# Altium schematic sheet Pedalbox.SchDoc
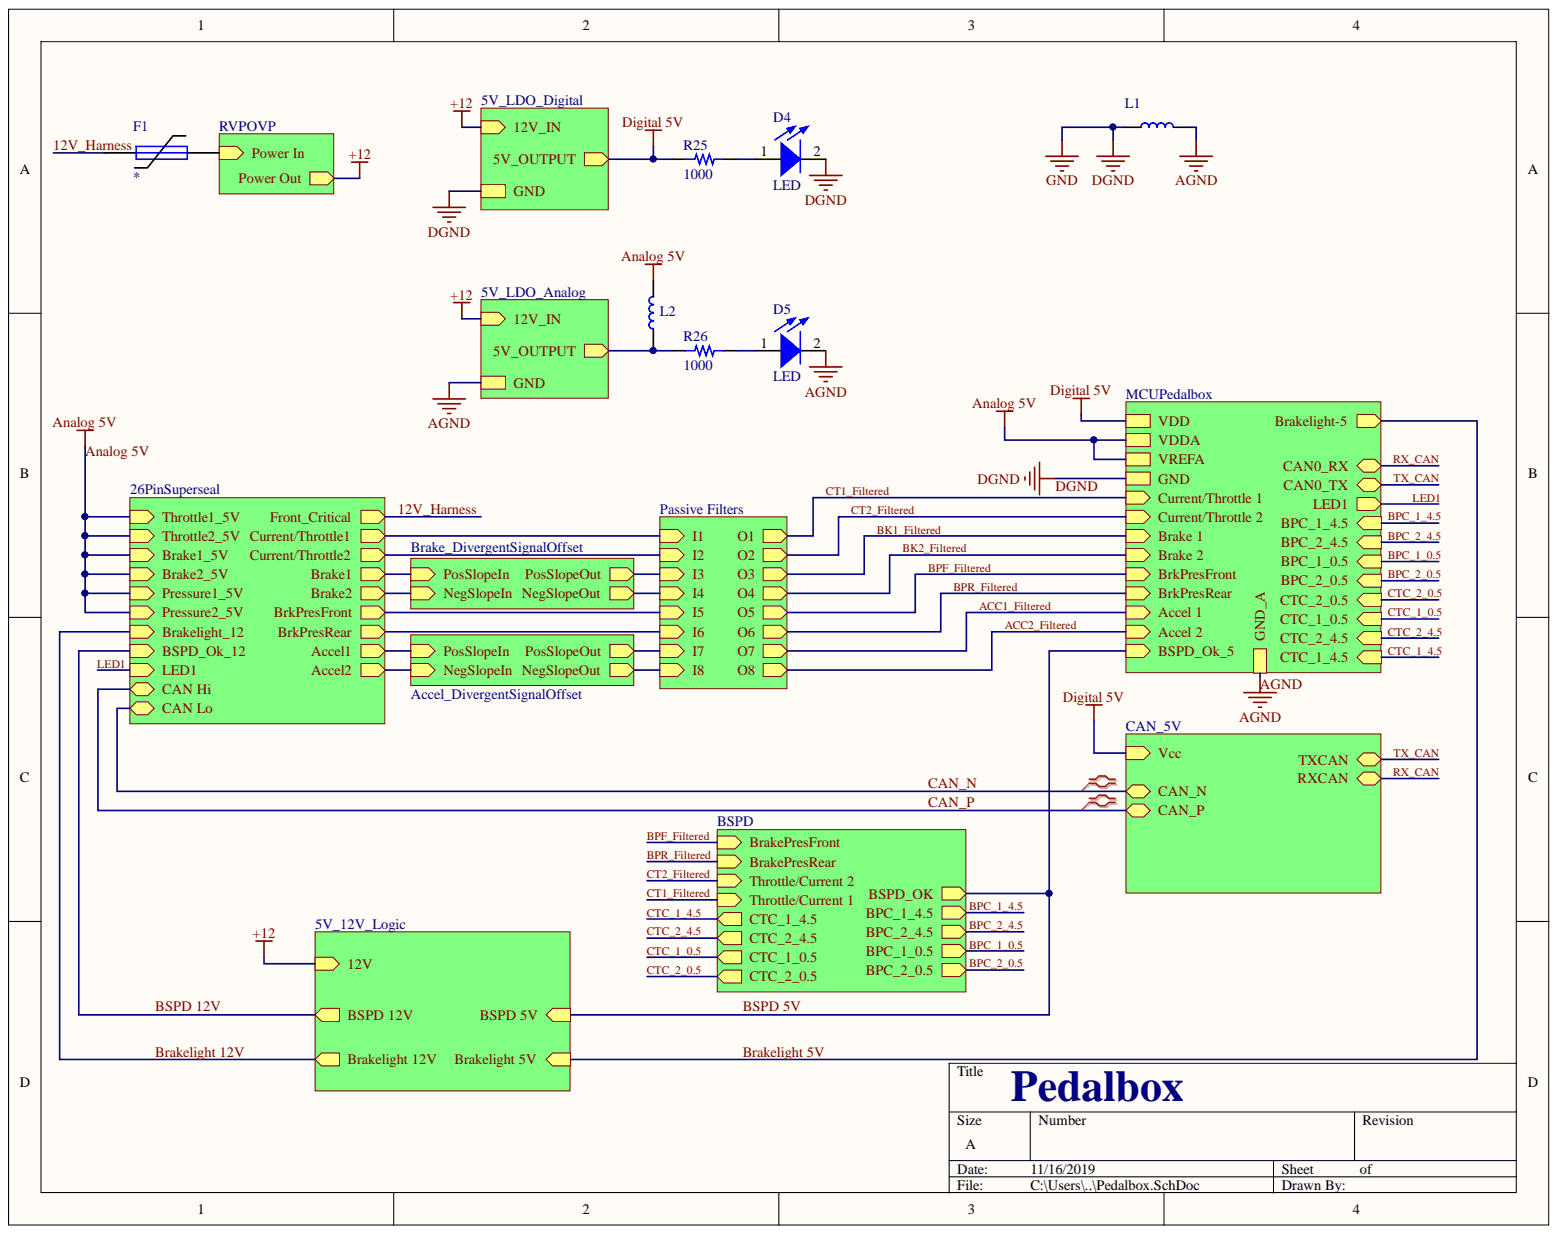
[diagram p0: Pedalbox.SchDoc]

## Parts
D4  LED  [0805_LED]
D5  LED  [0805_LED]
F1  =I_trip  [PTC Fuse 2920/07351]
L1  MPZ1608S221ATA00  [0603]  (value not drawn)
L2  MPZ1608S221ATA00  [0603]  (value not drawn)
R25  resistance: 1000  [0603]  (hidden comment: resistor)
R26  resistance: 1000  [0603]  (hidden comment: resistor)

## Nets
Digital 5V: R25.1
+12: F1.1  [also labelled 12V_Harness]
AGND: D5.2 L1.2
Analog 5V: L2.2
DGND: D4.2 L1.1
NetD4_1: D4.1 R25.2
NetD5_1: D5.1 R26.2
NetF1_2: F1.2
NetL2_1: L2.1 R26.1
ACC1_Filtered:
ACC2_Filtered:
Accel1:
Accel2:
BK1_Filtered:
BK2_Filtered:
BPC_1_0.5:
BPC_1_4.5:
BPC_2_0.5:
BPC_2_4.5:
BPF_Filtered:
BPR_Filtered:
Brake1:
Brake2:
Brakelight 5V:
Brakelight 12V:
BrkPresFront:
BrkPresRear:
BSPD 5V:
BSPD 12V:
CAN_N:
CAN_P:
CT1_Filtered:
CT2_Filtered:
CTC_1_0.5:
CTC_1_4.5:
CTC_2_0.5:
CTC_2_4.5:
Current/Throttle1:
Current/Throttle2:
LED1:
NegSlopeOut:
PosSlopeOut:
RX_CAN:
TX_CAN:

## Library data of the parts
D4 D5 = 19: Generic 0805 LED; Database Table Name: diodes; numcircuits: 1; Component Kind: Standard; id: 19; name: 0805 LED; package: 0805; quantity: 0; vr: 1; Library Name: altlib.DbLib
F1 = 11: Polymeric PTC Resettable Fuse 24V 2A Ih Surfa; Database Table Name: fuses; iinterrupt: 0; itrip: 4; Name: 0ZCF0200AF2C; vmax: 24; Component Kind: Standard; id: 11; LatestRevisionDate: 17-Jul-2002; LatestRevisionNote: Re-released for DXP Platform.; link: https://www.digikey.com/product-detail/en/bel-fuse-inc/0ZCF0200AF2C/507-1749-1-ND/4156183; PackageDescription: Thru-Hole; 2 Leads; PackageReference: PIN-W2/E2.8; Published: 8-Jun-2000; quantity: 0; Simulation: FUSE; createdby: Kevin; Library Name: altlib.DbLib; Publisher: Altium Limited
L1 L2 = 10: 220 Ohms @ 100MHz 1 Power Line Ferrite Bead 0; Database Table Name: inductors; imax: 2.20000004768372; MF: TDK; resistance: 50mOhm; tolerance: 0; Component Kind: Standard; Digi-Key_Part_Number: 445-1565-1-ND; Digi-Key_Purchase_URL: https://www.digikey.com/product-detail/en/tdk-corporation/MPZ1608S221ATA00/445-1565-1-ND/571895?utm_source=snapeda&utm_medium=aggregator&utm_campaign=symbol; id: 10; link: https://www.digikey.com/product-detail/en/tdk-corporation/MPZ1608S221ATA00/445-1565-1-ND/571895; MP: MPZ1608S221ATA00; name: MPZ1608S221ATA00; Package: 0603; quantity: 0; SnapEDA_Link: https://www.snapeda.com/parts/MPZ1608S221ATA00/TDK-Lambda%20Americas%20Inc/view-part/?ref=snap; createdby: Kevin; Library Name: altlib.DbLib
R25 R26 = 1: Database Table Name: resistors; name: 0603 Resistor; percent tolerance: 5; Component Kind: Standard; id: 1; package: 0603; quantity: 0; Library Name: altlib.DbLib
